# Altium schematic sheet LIDO-HW.100.VinAndPower.SchDoc(LIDO-HW.100.VinAndPower)
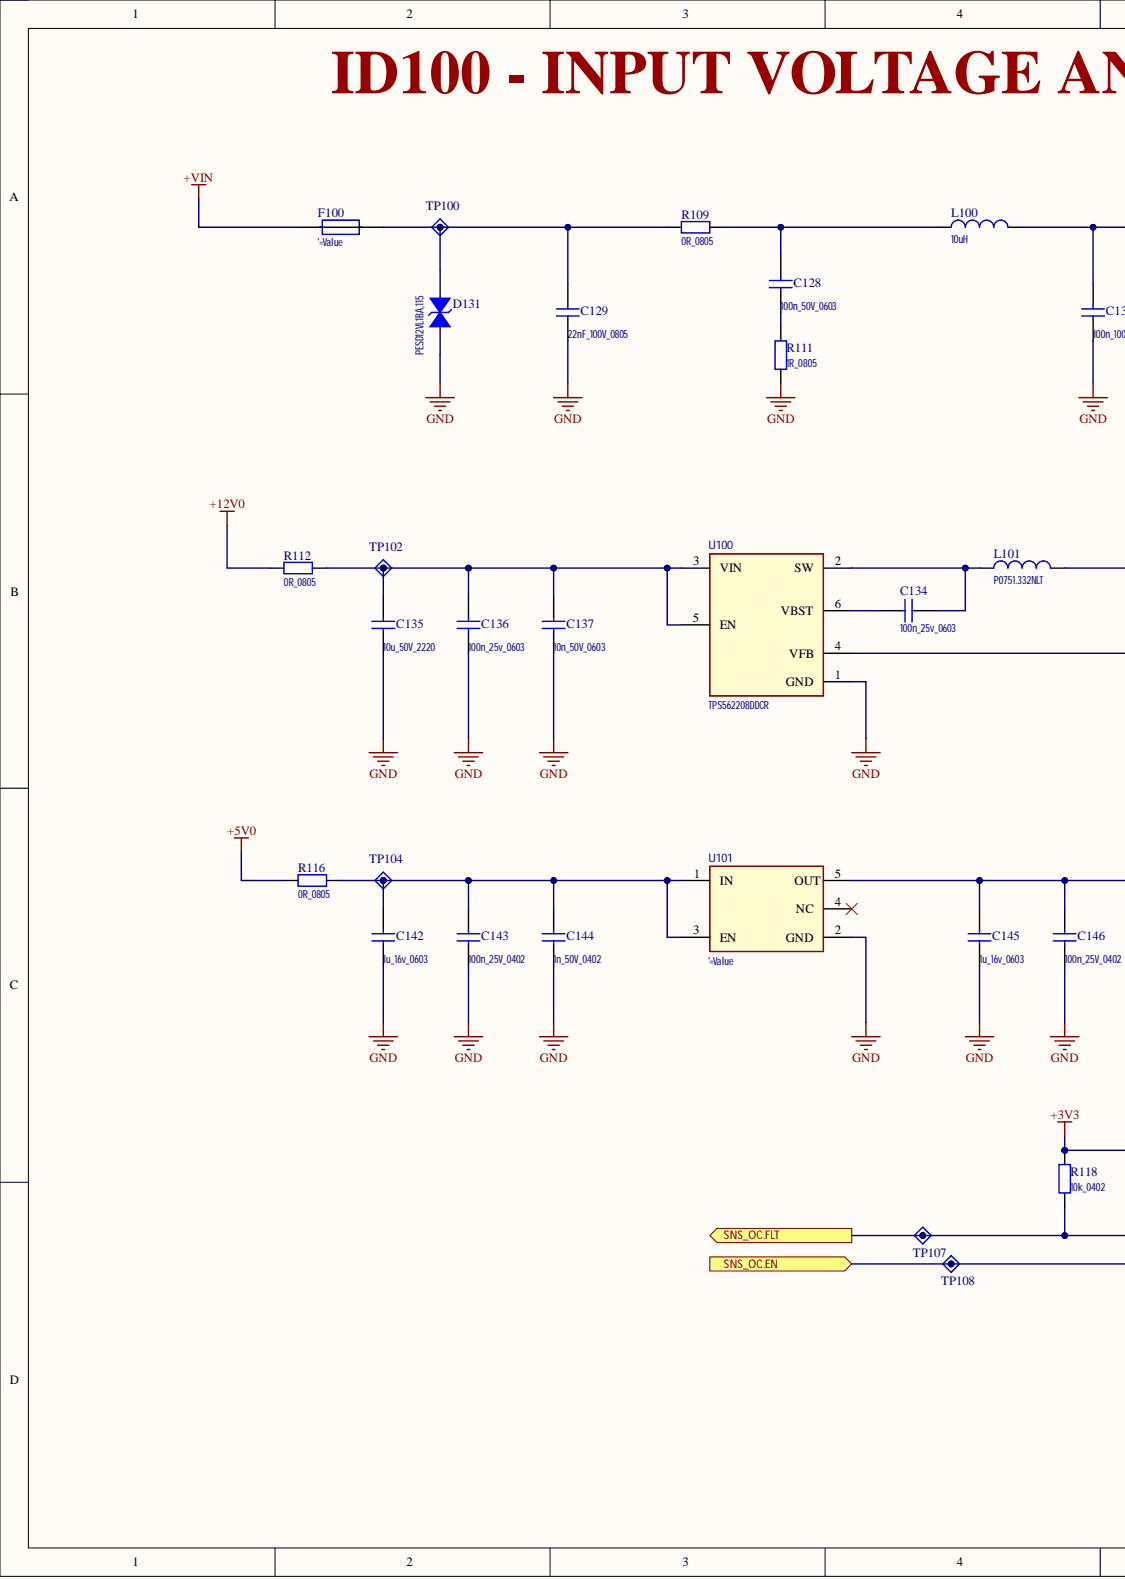
[diagram p0: LIDO-HW.100.VinAndPower.SchDoc, left]
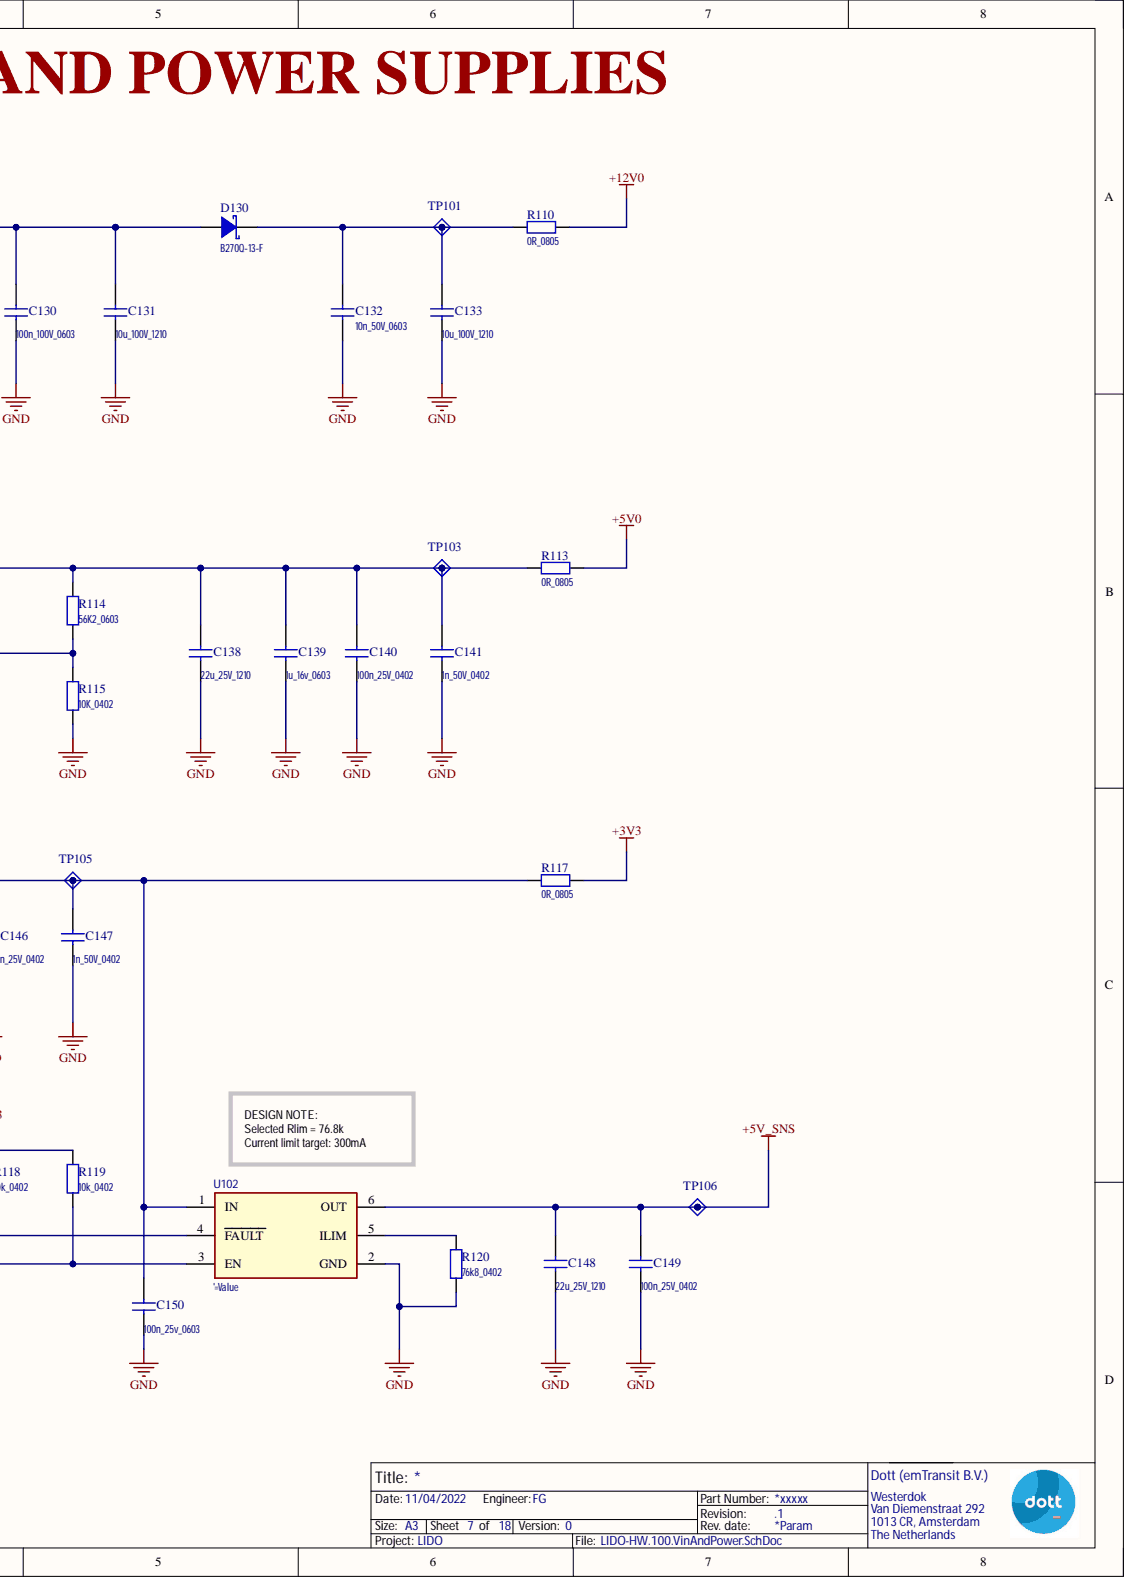
[diagram p1: LIDO-HW.100.VinAndPower.SchDoc, right]

## Parts
C128  100n_50V_0603
C129  22nF_100V_0805
C130  100n_100V_0603
C131  10u_100V_1210
C132  10n_50V_0603
C133  10u_100V_1210
C134  100n_25v_0603
C135  10u_50V_2220
C136  100n_25v_0603
C137  10n_50V_0603
C138  22u_25V_1210
C139  1u_16v_0603
C140  100n_25V_0402
C141  1n_50V_0402
C142  1u_16v_0603
C143  100n_25V_0402
C144  1n_50V_0402
C145  1u_16v_0603
C146  100n_25V_0402
C147  1n_50V_0402
C148  22u_25V_1210
C149  100n_25V_0402
C150  100n_25v_0603
D130  B270Q-13-F
D131  PESD12VL1BA,115
F100  '=Value
L100  10uH
L101  P0751.332NLT
R109  0R_0805
R110  0R_0805
R111  1R_0805
R112  0R_0805
R113  0R_0805
R114  56K2_0603
R115  10K_0402
R116  0R_0805
R117  0R_0805
R118  10k_0402
R119  10k_0402
R120  76k8_0402
TP100  TestPoint_PWR  (value not drawn)  (no BOM)
TP101  TestPoint_PWR  (value not drawn)  (no BOM)
TP102  TestPoint_PWR  (value not drawn)  (no BOM)
TP103  TestPoint_PWR  (value not drawn)  (no BOM)
TP104  TestPoint_PWR  (value not drawn)  (no BOM)
TP105  TestPoint_PWR  (value not drawn)  (no BOM)
TP106  TestPoint_PWR  (value not drawn)  (no BOM)
TP107  TestPoint_PWR  (value not drawn)  (no BOM)
TP108  TestPoint_PWR  (value not drawn)  (no BOM)
U100  TPS562208DDCR
U101  '=Value
U102  '=Value

## Ports
SNS_OC.EN, SNS_OC.FLT

## Nets
+3V3: R117.1 R118.2 R119.2
+5V0: R113.1 R116.2
+5V_SNS: C148.1 C149.1 TP106.1 U102.6(OUT)
+12V0: R110.1 R112.2
+VIN: F100.1
GND: C129.2 C130.2 C131.2 C132.2 C133.2 C135.2 C136.2 C137.2 C138.2 C139.2 C140.2 C141.2 C142.2 C143.2 C144.2 C145.2 C146.2 C147.2 C148.2 C149.2 C150.2 D131.A R111.2 R115.2 R120.1 U100.1(GND) U101.2(GND) U102.2(GND)
SNS_OC.EN: R119.1 TP108.1 U102.3(EN) ; port SNS_OC.EN
SNS_OC.FLT: R118.1 TP107.1 U102.4(FAULT) ; port SNS_OC.FLT
NetC128_1: C128.1 L100.1 R109.1
NetC128_2: C128.2 R111.1
NetC129_1: C129.1 D131.C F100.2 R109.2 TP100.1
NetC130_1: C130.1 C131.1 D130.A L100.2
NetC132_1: C132.1 C133.1 D130.C R110.2 TP101.1
NetC134_1: C134.1 U100.6(VBST)
NetC134_2: C134.2 L101.1 U100.2(SW)
NetC135_1: C135.1 C136.1 C137.1 R112.1 TP102.1 U100.3(VIN) U100.5(EN)
NetC138_1: C138.1 C139.1 C140.1 C141.1 L101.2 R113.2 R114.1 TP103.1
NetC142_1: C142.1 C143.1 C144.1 R116.1 TP104.1 U101.1(IN) U101.3(EN)
NetC145_1: C145.1 C146.1 C147.1 C150.1 R117.2 TP105.1 U101.5(OUT) U102.1(IN)
NetR114_2: R114.2 R115.1 U100.4(VFB)
NetR120_2: R120.2 U102.5(ILIM)

Not connected: U101 4(NC)

## Library data of the parts
C128-C150 = Ceramic: Ceramic CAP Symbol; Component Kind: Standard; Library Name: 01_Capacitors.SchLib
D130 = Schottky_A: Schottky Diode Symbol; Component Kind: Standard; Library Name: 06_Diodes.SchLib
D131 = BI-TVS_DIODE_B: Bidirectional TVS Diode Symbol; Component Kind: Standard; Library Name: 04_Circuit_Protection.SchLib
F100 = FUSE: Fuse Symbol; Component Kind: Standard; Library Name: 04_Circuit_Protection.SchLib
L100 L101 = Inductor: Inductor Symbol; Component Kind: Standard; Library Name: 03_Inductors_Coils_Chokes.SchLib
R109-R120 = Resistor: Resistor Symbol; Component Kind: Standard; Library Name: 02_Resistors.SchLib
TP100-TP108 = TestPoint_A: Test Point Symbol verion A; 00_Name: TP_XXmm; 01_Diameter: 1mm; Library Name: 31_MFG_Elements.SchLib
U100 = TPS562208: TI  4.5-V to 17-V Input, 2-A Synchronous Step-Down Voltage Regulator  in 6-Pin SOT-23; Component Kind: Standard; Library Name: 15_IC_PMIC.SchLib
U101 = TLV74333PD: IC REG LINEAR 3.3V 300MA SOT23-5; Component Kind: Standard; Library Name: 15_IC_PMIC.SchLib
U102 = TPS2552_A: TI, Power Switch/Driver 1:1 N-Channel 1.5A SOT-23-6; Component Kind: Standard; Library Name: 15_IC_PMIC.SchLib
